# Altium schematic sheet schematic.SchDoc(schematic)
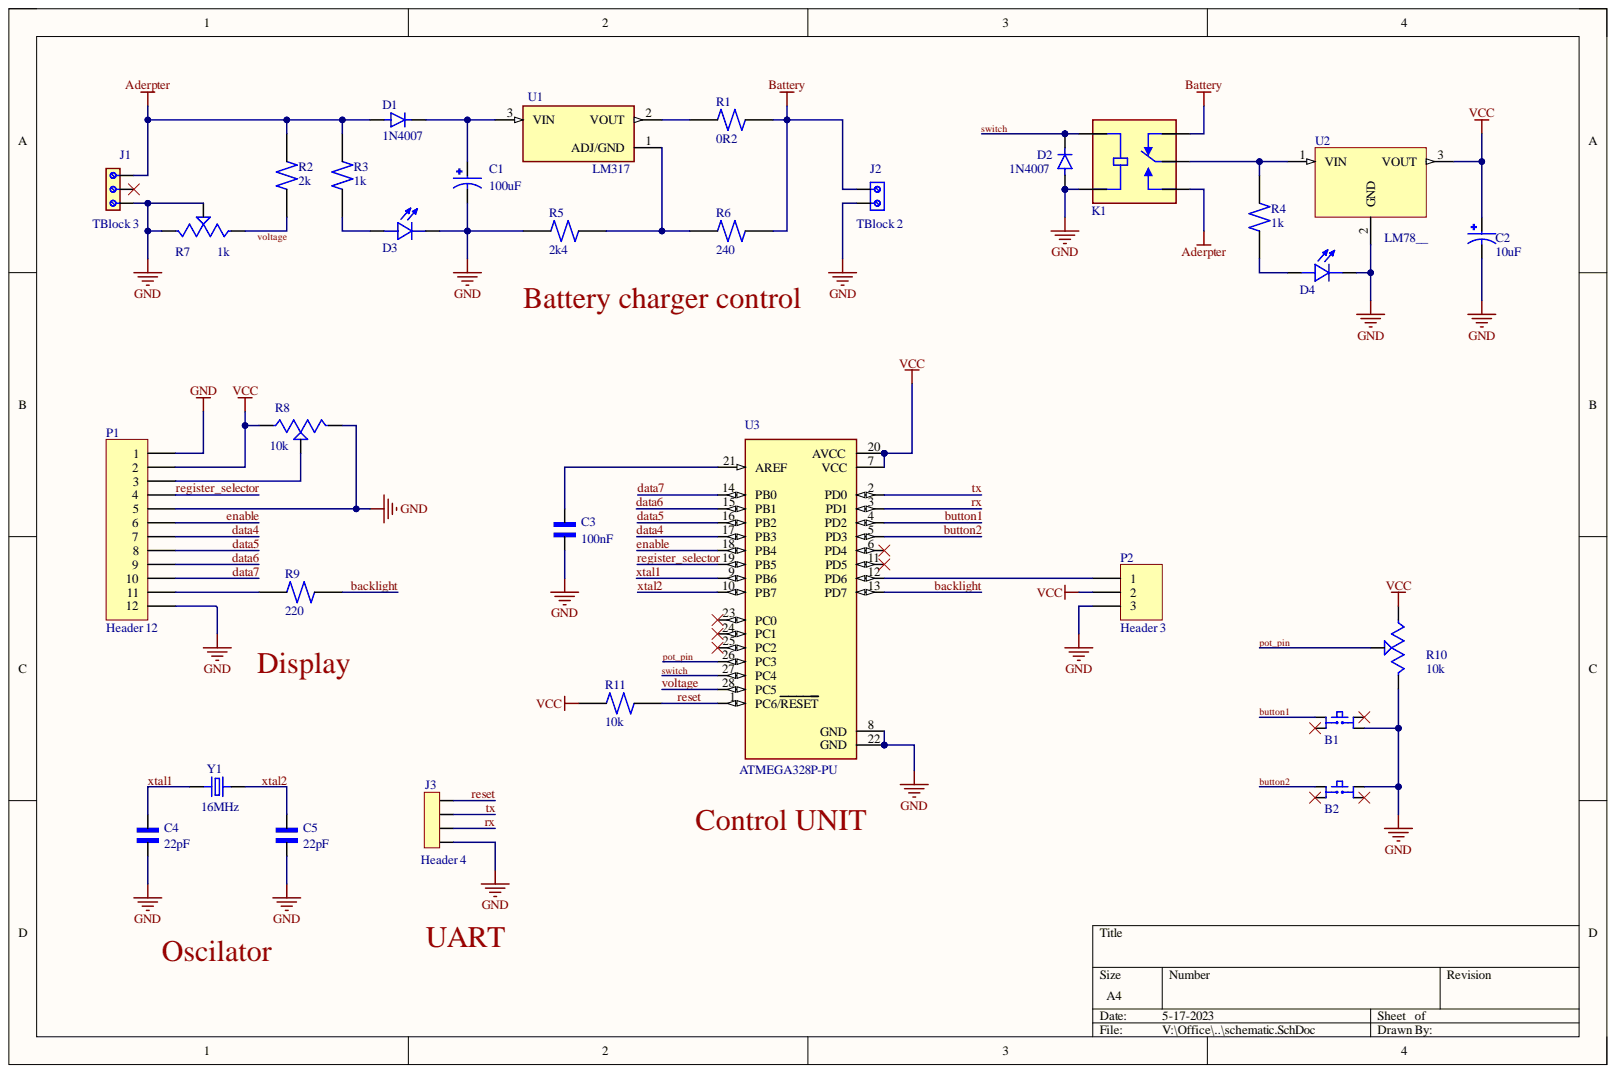
[diagram p0: schematic.SchDoc]

## Parts
B1  Push button  [SW_TS02-66-95-BK-260-LCR-D]  (value not drawn)
B2  Push button  [SW_TS02-66-95-BK-260-LCR-D]  (value not drawn)
C1  100uF  [CAPPRD500W50D500H1100]
C2  10uF  [CAPPRD500W50D500H1100]
C3  100nF  [CAPRB500W50L450T300H550]
C4  22pF  [CAPRB500W50L450T300H550]
C5  22pF  [CAPRB500W50L450T300H550]
D1  1N4007  [DIOAD1060W80L520D270]
D2  1N4007  [DIOAD1060W80L520D270]
D3  L08R5000Q1  [LEDRD254W57D500H1070]  (value not drawn)
D4  L08R5000Q1  [LEDRD254W57D500H1070]  (value not drawn)
J1  TBlock 3  [Terminal block - 3Pins]
J2  TBlock 2  [691111710002]
J3  Header 4  [SULLINS_PPTC041LFBN-RC]
K1  JQC-3F-1C-24VDC  [RELAY_JQC-3F-1C-24VDC]  (value not drawn)
P1  Header 12  [HDR1X12]
P2  Header 3  [HDR1X3]
R1  0R2  [RESAD1100W55L680D260]
R2  2k  [RESAD1100W55L680D260]
R3  1k  [RESAD1100W55L680D260]
R4  1k  [RESAD1100W55L680D260]
R5  2k4  [RESAD1100W55L680D260]
R6  240  [RESAD1100W55L680D260]
R7  1k  [TRIM_3306F-1-105]
R8  10k  [TRIM_3306F-1-105]
R9  220  [RESAD1100W55L680D260]
R10  10k  [TRIM_3352T-1-254LF]
R11  10k  [RESAD1100W55L680D260]
U1  LM317  [TO255P1020X450X1968-3]
U2  LM78__  [TO255P1020X450X1968-3]
U3  ATMEGA328P-PU  [DIP794W46P254L2967H457Q28B]
Y1  16MHz  [XTAL_ECS-160-20-4X]

## Nets
Aderpter: D1.A J1.3 K1.NO R2.2 R3.2
Battery: J2.1 K1.NC R1.2 R6.2
GND: B1.4 B2.3 C1.N C2.N C3.1 C4.1 C5.1 D2.A D3.C D4.C J1.1 J2.2 J3.4 K1.COIL2 P1.1 P1.5 P1.12 P2.3 R5.1 R7.2 R7.3 R8.3 R10.3 U2.2 U3.8(GND) U3.22(GND)
VCC: C2.P P1.2 P2.2 R8.1 R10.1 R11.2 U2.3 U3.7(VCC) U3.20(AVCC)
backlight: R9.2 U3.13(PD7)
button1: B1.1 U3.4(PD2)
button2: B2.1 U3.5(PD3)
data4: P1.7 U3.17(PB3)
data5: P1.8 U3.16(PB2)
data6: P1.9 U3.15(PB1)
data7: P1.10 U3.14(PB0)
enable: P1.6 U3.18(PB4)
pot_pin: R10.2 U3.26(PC3)
register_selector: P1.4 U3.19(PB5)
reset: J3.1 R11.1 U3.1(PC6/RESET)
rx: J3.3 U3.3(PD1)
switch: D2.C K1.COIL1 U3.27(PC4)
tx: J3.2 U3.2(PD0)
voltage: R2.1 R7.1 U3.28(PC5)
xtal1: C4.2 U3.9(PB6) Y1.1
xtal2: C5.2 U3.10(PB7) Y1.2
NetC1_P: C1.P D1.C U1.3
NetC3_2: C3.2 U3.21(AREF)
NetD3_A: D3.A R3.1
NetD4_A: D4.A R4.1
NetK1_COM: K1.COM R4.2 U2.1
NetP1_3: P1.3 R8.2
NetP1_11: P1.11 R9.1
NetP2_1: P2.1 U3.12(PD6)
NetR1_1: R1.1 U1.2
NetR5_2: R5.2 R6.1 U1.1

Not connected: B1 2 3; B2 2 4; J1 2; U3 6(PD4) 11(PD5) 23(PC0) 24(PC1) 25(PC2)

## Library data of the parts
C1 C2 = Electrolytic capacitor: FS-A; MF: {'manufacturer': u'Panasonic'}; MP: EEUFS0J221B; Availability: Unavailable; Component Kind: Standard; Package: None; Price: None; Library Name: Installed library.IntLib
C3-C5 = Ceramic capacitor: 2200 pF Â±10% 250V Ceramic Capacitor X7R Radial; MF: TDK; MP: FG24X7R2E222KNT06; Check_prices: https://www.snapeda.com/parts/FG24X7R2E222KNT06/TDK/view-part/?ref=eda; Component Kind: Standard; Digi-Key_Part_Number: 445-173386-1-ND; Package: Radial TDK; Purchase-URL: https://pricing.snapeda.com/search/part/FG24X7R2E222KNT06/?ref=eda; SnapEDA_Link: https://www.snapeda.com/parts/FG24X7R2E222KNT06/TDK/view-part/?ref=snap; Library Name: Installed library.IntLib
D1 D2 = 1N4007: Diode Switching 1KV 1A 2-Pin DO-41; Availability: Unavailable; Component Kind: Standard; Library Name: Components.IntLib; MP: 1N4007; Package: None; Price: None
D3 D4 = LED: LED, Orange, Through Hole, T-1 3/4 (5mm), 30 mA, 2.1 V, 635 nm; MF: {'manufacturer': u'LED Technology'}; Availability: Unavailable; Component Kind: Standard; MP: L08R5000Q1; Package: None; Price: None; Library Name: Installed library.IntLib
J1 = 3Pins Terminal block: 5.08 MM TERMINAL BLOCK;; MF: Wurth Electronics; MP: 691216510003S; Availability: In Stock; Check_prices: https://www.snapeda.com/parts/691216510003S/Wurth+Electronics+Inc/view-part/?ref=eda; Component Kind: Standard; Package: None; Price: None; Purchase-URL: https://pricing.snapeda.com/search/part/691216510003S/?ref=eda; SnapEDA_Link: https://www.snapeda.com/parts/691216510003S/Wurth+Electronics+Inc/view-part/?ref=snap; Library Name: Installed library.IntLib
J2 = Terminal Block - 2Pins: 2 Position Wire to Board Terminal Block Horizontal with Board 0.197 _5.00mm_ Through Hole; MF: Wurth Electronics; Availability: Unavailable; Component Kind: Standard; MP: 691111710002; Package: None; Price: None; SnapEDA_Link: https://www.snapeda.com/parts/691111710002/Wurth%20Electronics%20Inc./view-part/1195092/?ref=snap; Library Name: Installed library.IntLib
J3 = Female header - 4Pins: 4 Position Header Connector Through Hole; MF: Sullins Connector; MP: PPTC041LFBN-RC; Availability: Warning; Check_prices: https://www.snapeda.com/parts/PPTC041LFBN-RC/Sullins%20Connector%20Solutions/view-part/285917/?ref=eda; Component Kind: Standard; Package: None; Price: None; Purchase-URL: https://pricing.snapeda.com/search/part/PPTC041LFBN-RC/?ref=eda; SnapEDA_Link: https://www.snapeda.com/parts/PPTC041LFBN-RC/Sullins%20Connector%20Solutions/view-part/285917/?ref=snap; Library Name: Installed library.IntLib
K1 = Relay (Standard): Miniature PCB Relay 24VDC, 10A 250VAC, 15A 125VAC, 10A 250VAC; Availability: Unavailable; Component Kind: Standard; MP: JQC-3F-1C-24VDC; Package: None; Price: None; Library Name: Installed library.IntLib
P1 = Header 12: Header, 12-Pin; Component Kind: Standard; LatestRevisionDate: 17-Jul-2002; LatestRevisionNote: Re-released for DXP Platform.; Library Name: Miscellaneous Connectors.IntLib; Signal Integrity: Connector; Publisher: Altium Limited
P2 = Header 3: Header, 3-Pin; Component Kind: Standard; LatestRevisionDate: 17-Jul-2002; LatestRevisionNote: Re-released for DXP Platform.; Library Name: Miscellaneous Connectors.IntLib; Signal Integrity: Connector; Publisher: Altium Limited
R1-R6 R9 R11 = Resistor (0.25W): (MFR-25FBF52-10K) 10,2 kOhm bei 1% 0,25W, 1/4W Durchbohrlochwiderstand Axial Metal Film; MF: Yageo; Component Kind: Standard; Digi-Key_Part_Number: 10.2KXBK-ND; MP: MFR-25FBF52-10K; Package: Axial Yageo; Purchase-URL: https://pricing.snapeda.com/search/part/MFR-25FBF52-10K/?ref=eda; SnapEDA_Link: https://www.snapeda.com/parts/MFR-25FBF52-10K/Yageo/view-part/424333/?ref=snap; Library Name: Installed library.IntLib
R7 R8 = Potentiometer1: (3306F-1-105) 1 MOhms 0.2W, 1/5W PC Pins Through Hole Trimmer Potentiometer Cermet 1 Turn Top Adjustment; MF: Bourns; Availability: Good; Check_prices: https://www.snapeda.com/parts/3306F-1-105/Bourns%20-%20J.W.%20Miller/view-part/862876/?ref=eda; Component Kind: Standard; MP: 3306F-1-105; Package: 3306F Bourns; Price: None; Purchase-URL: https://pricing.snapeda.com/search/part/3306F-1-105/?ref=eda; SnapEDA_Link: https://www.snapeda.com/parts/3306F-1-105/Bourns%20-%20J.W.%20Miller/view-part/862876/?ref=snap; Library Name: Installed library.IntLib
R10 = Variable resistor: RESISTOR, VARIABLE, 250KOHM, 0.5W, Â±20%; Track Resistance:250kohm; No. of Turns:1; Resistance Tolerance:Â± 20%; Temperature Coefficient:Â± 100ppm/Â°C; Power Rating:500mW; Potentiometer Mounting:Through Hole ;RoHS Compliant: Yes; MF: Bourns; MP: 3352T-1-254LF; Availability: In Stock; Check_prices: https://www.snapeda.com/parts/3352T-1-254LF/Bourns/view-part/?ref=eda; Component Kind: Standard; Package: 3352T-3 Bourns; Price: None; Purchase-URL: https://pricing.snapeda.com/search/part/3352T-1-254LF/?ref=eda; SnapEDA_Link: https://www.snapeda.com/parts/3352T-1-254LF/Bourns/view-part/?ref=snap; Library Name: Installed library.IntLib
U1 = LD1117V33: Availability: Unavailable; Component Kind: Standard; MP: LD1117V33; Package: None; Price: None; Library Name: Installed library.IntLib
U2 = LM78__: MP: LM7805CT/NOPB; Availability: Unavailable; Component Kind: Standard; Library Name: Voltage regulator.IntLib; Package: None; Price: None
U3 = ATMEGA328P-PU: ATmega Series 20 MHz 32 KB Flash 2 KB SRAM 8-Bit Microcontroller - DIP-28; Availability: Unavailable; Component Kind: Standard; MP: ATMEGA328P-PU; Package: None; Price: None; Library Name: Installed library.IntLib
Y1 = Crystal oscilator: CRYSTAL T/H; MF: {'manufacturer': u'ECS Inc.'}; MP: ECS-160-S-4; Availability: Unavailable; Component Kind: Standard; Package: None; Price: None; Library Name: Installed library.IntLib
B1 B2 = Push button: Component Kind: Standard; Library Name: Installed library.IntLib
